# Altium schematic sheet Sheet2.SchDoc(Sheet2)
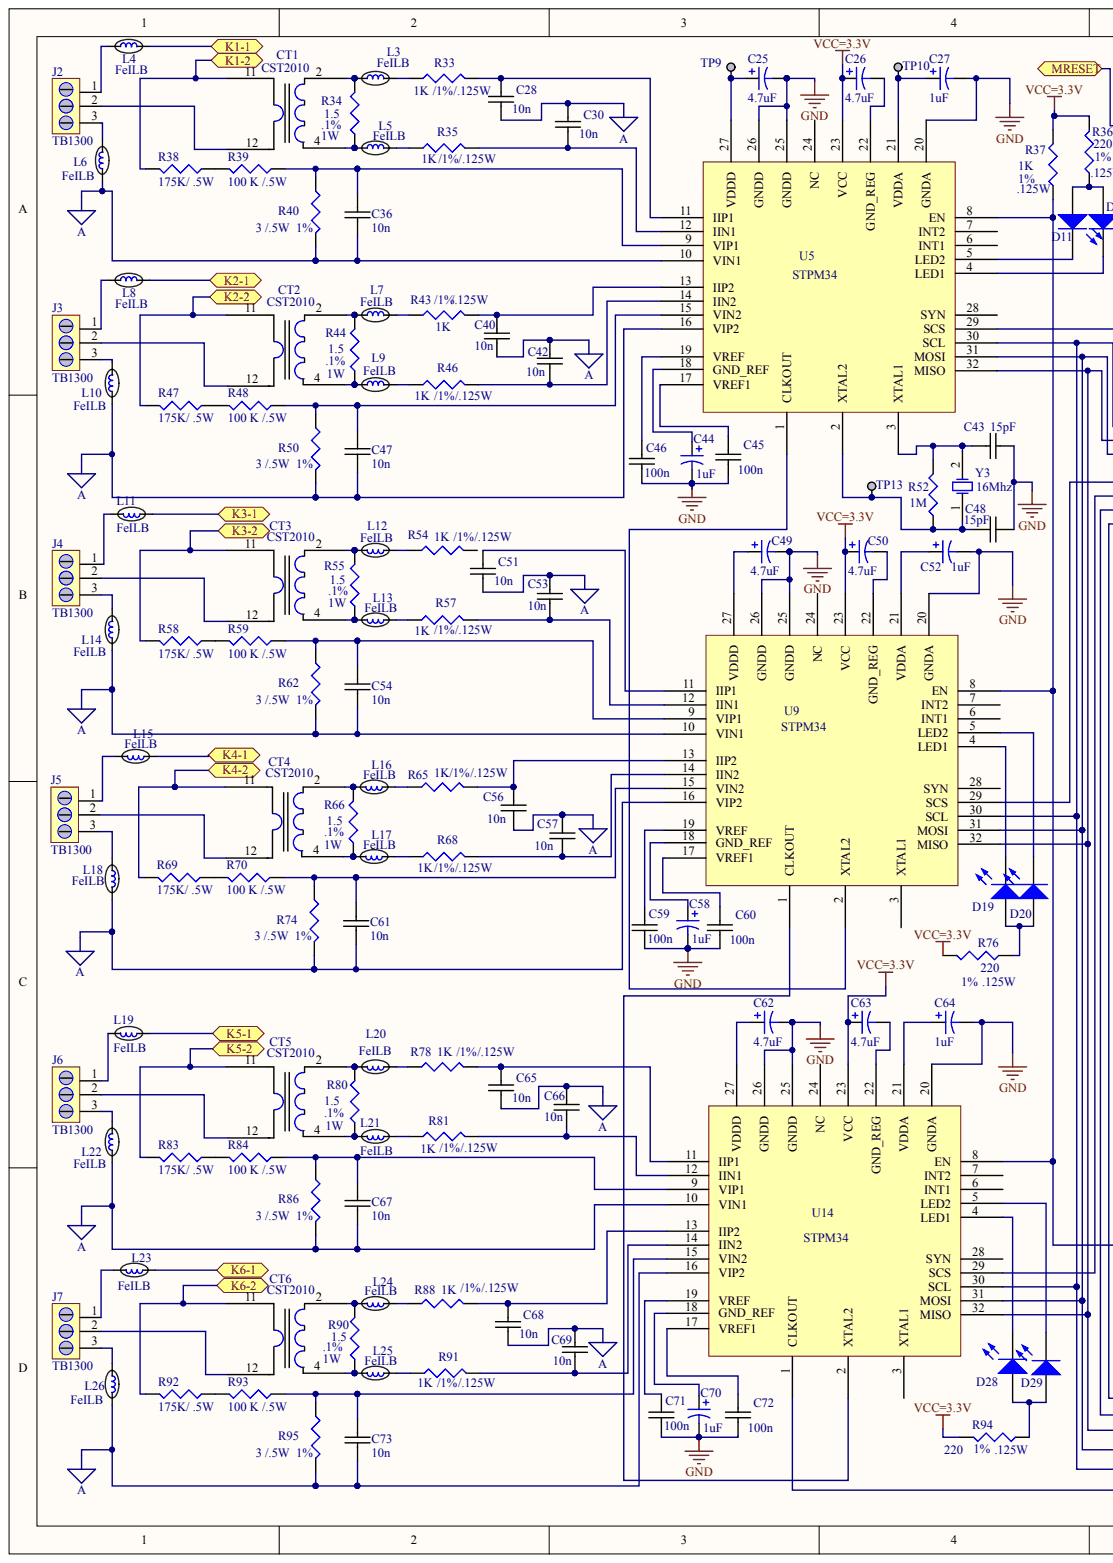
[diagram p0: Sheet2.SchDoc, left]
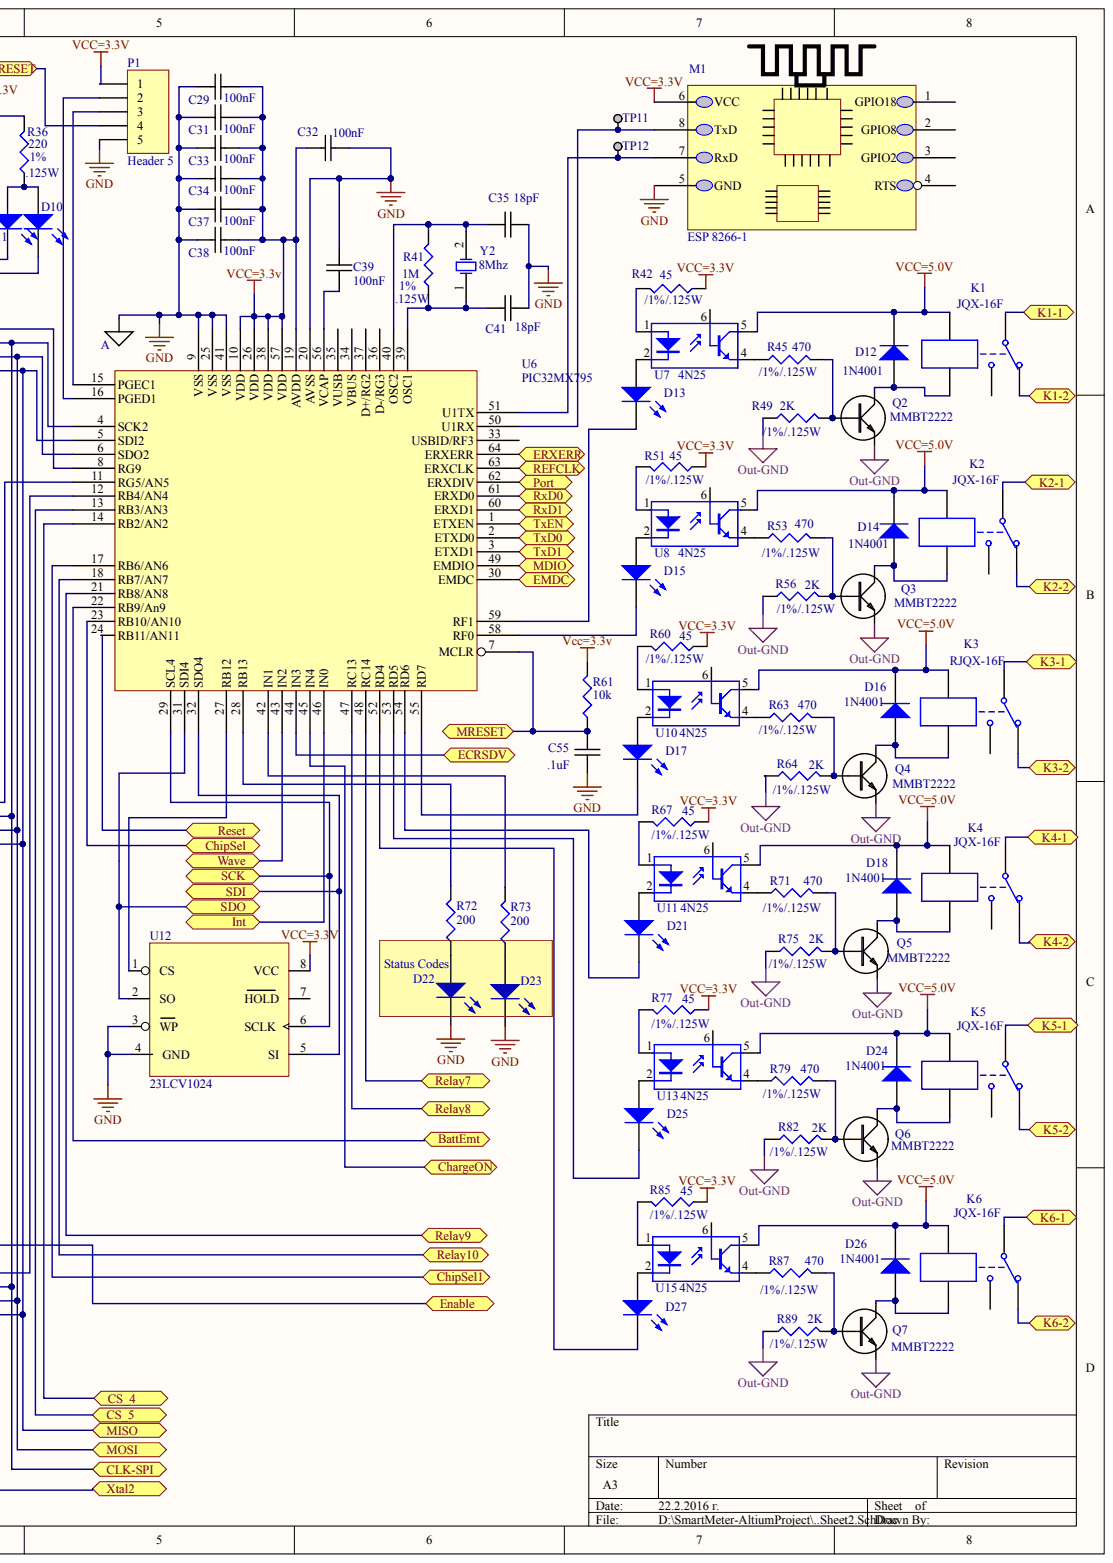
[diagram p1: Sheet2.SchDoc, right]

## Parts
1  AGND  (value not drawn)
C25  4.7uF
C26  4.7uF
C27  1uF
C28  10n
C29  100nF
C30  10n
C31  100nF
C32  100nF
C33  100nF
C34  100nF
C35  18pF
C36  10n
C37  100nF
C38  100nF
C39  100nF
C40  10n
C41  18pF
C42  10n
C43  15pF
C44  1uF
C45  100n
C46  100n
C47  10n
C48  15pF
C49  4.7uF
C50  4.7uF
C51  10n
C52  1uF
C53  10n
C54  10n
C55  .1uF
C56  10n
C57  10n
C58  1uF
C59  100n
C60  100n
C61  10n
C62  4.7uF
C63  4.7uF
C64  1uF
C65  10n
C66  10n
C67  10n
C68  10n
C69  10n
C70  1uF
C71  100n
C72  100n
C73  10n
CT1  CST2010
CT2  CST2010
CT3  CST2010
CT4  CST2010
CT5  CST2010
CT6  CST2010
D10  LED2  (value not drawn)
D11  LED2  (value not drawn)
D12  1N4001
D13  LED2  (value not drawn)
D14  1N4001
D15  LED2  (value not drawn)
D16  1N4001
D17  LED2  (value not drawn)
D18  1N4001
D19  LED2  (value not drawn)
D20  LED2  (value not drawn)
D21  LED2  (value not drawn)
D22  LED2  (value not drawn)
D23  LED2  (value not drawn)
D24  1N4001
D25  LED2  (value not drawn)
D26  1N4001
D27  LED2  (value not drawn)
D28  LED2  (value not drawn)
D29  LED2  (value not drawn)
J2  TB1300
J3  TB1300
J4  TB1300
J5  TB1300
J6  TB1300
J7  TB1300
K1  JQX-16F
K2  JQX-16F
K3  RJQX-16F
K4  JQX-16F
K5  JQX-16F
K6  JQX-16F
L3  FeILB
L4  FeILB
L5  FeILB
L6  FeILB
L7  FeILB
L8  FeILB
L9  FeILB
L10  FeILB
L11  FeILB
L12  FeILB
L13  FeILB
L14  FeILB
L15  FeILB
L16  FeILB
L17  FeILB
L18  FeILB
L19  FeILB
L20  FeILB
L21  FeILB
L22  FeILB
L23  FeILB
L24  FeILB
L25  FeILB
L26  FeILB
M1  ESP 8266-1
P1  Header 5
Q2  MMBT2222
Q3  MMBT2222
Q4  MMBT2222
Q5  MMBT2222
Q6  MMBT2222
Q7  MMBT2222
R33  1K
R34  1.5
R35  1K
R36  220
R37  1K  value 1K 1%
R38  175K/ .5W
R39  100 K /.5W
R40  3 /.5W  1%
R41  1M
R42  45
R43  1K
R44  1.5
R45  470
R46  1K
R47  175K/ .5W
R48  100 K /.5W
R49  2K
R50  3 /.5W  1%
R51  45
R52  1M
R53  470
R54  1K
R55  1.5
R56  2K
R57  1K
R58  175K/ .5W
R59  100 K /.5W
R60  45
R61  10k
R62  3 /.5W  1%
R63  470
R64  2K
R65  1K
R66  1.5
R67  45
R68  1K
R69  175K/ .5W
R70  100 K /.5W
R71  470
R72  200
R73  200
R74  3 /.5W  1%
R75  2K
R76  220
R77  45
R78  1K
R79  470
R80  1.5
R81  1K
R82  2K
R83  175K/ .5W
R84  100 K /.5W
R85  45
R86  3 /.5W  1%
R87  470
R88  1K
R89  2K
R90  1.5
R91  1K
R92  175K/ .5W
R93  100 K /.5W
R94  220
R95  3 /.5W  1%
TP9  TESTPOINT  (value not drawn)
TP10  TESTPOINT  (value not drawn)
TP11  TESTPOINT  (value not drawn)
TP12  TESTPOINT  (value not drawn)
TP13  TESTPOINT  (value not drawn)
U5  STPM34
U6  PIC32MX795
U7  4N25
U8  4N25
U9  STPM34
U10  4N25
U11  4N25
U12  23LCV1024
U13  4N25
U14  STPM34
U15  4N25
Y2  8Mhz
Y3  16Mhz

## Ports
BATTEMT, CHARGEON, CHIPSEL, CHIPSEL1, CLK-SPI, CS_4, CS_5, ECRSDV, EMDC, ENABLE, ERXERR, INT, K1-1, K1-2, K2-1, K2-2, K3-1, K3-2, K4-1, K4-2, K5-1, K5-2, K6-1, K6-2, MDIO, MISO, MOSI, MRESET, PORT, REFCLK, RELAY7, RELAY8, RELAY9, RELAY10, RESET, RXD0, RXD1, SCK, SDI, SDO, TXD0, TXD1, TXEN, WAVE, XTAL2

## Nets
A: C28.1 C30.2 C36.1 C40.1 C42.2 C47.1 C51.1 C53.2 C54.1 C56.1 C57.2 C61.1 C65.1 C66.2 C67.1 C68.1 C69.2 C73.1 L6.1 L10.1 L14.1 L18.2 L22.1 L26.2 R40.2 R50.2 R62.2 R74.2 R86.2 R95.2 U5.10(VIN1) U5.16(VIP2) U9.10(VIN1) U9.16(VIP2) U14.10(VIN1) U14.16(VIP2)
GND: 1.VIN18 C25.2 C27.2 C29.1 C31.1 C32.2 C33.1 C34.1 C35.2 C37.1 C38.1 C39.2 C41.2 C43.2 C44.2 C45.1 C46.1 C48.2 C49.2 C52.2 C55.1 C58.2 C59.1 C60.1 C62.2 C64.2 C70.2 C71.1 C72.1 D22.2 D23.2 M1.5(GND) P1.5 U5.20(GNDA) U5.25(GNDD) U5.26(GNDD) U6.9(VSS) U6.20(AVSS) U6.25(VSS) U6.41(VSS) U9.20(GNDA) U9.25(GNDD) U9.26(GNDD) U12.3(WP) U12.4(GND) U14.20(GNDA) U14.25(GNDD) U14.26(GNDD)
OUT-GND: Q2.E Q3.E Q4.E Q5.E Q6.E Q7.E R49.1 R56.1 R64.1 R75.1 R82.1 R89.1
VCC=3.3V: C26.1 C29.2 C31.2 C32.1 C33.2 C34.2 C37.2 C38.2 C50.1 C63.1 M1.6(VCC) P1.1 R36.2 R37.2 R42.1 R51.1 R60.1 R61.2 R67.1 R76.2 R77.1 R85.1 R94.2 U5.23(VCC) U6.10(VDD) U6.19(AVDD) U6.26(VDD) U6.38(VDD) U6.57(VDD) U9.23(VCC) U12.8(VCC) U14.23(VCC)
VCC=5.0V: D12.2 D14.2 D16.2 D18.2 D24.2 D26.2 K1.4 K2.4 K3.4 K4.4 K5.4 K6.4 U7.5 U8.5 U10.5 U11.5 U13.5 U15.5
CLK-SPI: U5.30(SCL) U6.4(SCK2) U9.30(SCL) U14.30(SCL) ; port CLK-SPI
CS_4: U6.14(RB2/AN2) ; port CS_4
CS_5: U6.13(RB3/AN3) ; port CS_5
ECRSDV: U6.44(IN3) ; port ECRSDV
EMDC: U6.30(EMDC) ; port EMDC
ERXERR: U6.64(ERXERR) ; port ERXERR
K1-1: K1.1 L4.2 ; port K1-1
K1-2: CT1.11 K1.2 R38.2 ; port K1-2
K2-1: K2.1 L8.2 ; port K2-1
K2-2: CT2.11 K2.2 R47.2 ; port K2-2
K3-1: K3.1 L11.1 ; port K3-1
K3-2: CT3.11 K3.2 R58.2 ; port K3-2
K4-1: K4.1 L15.1 ; port K4-1
K4-2: CT4.11 K4.2 R69.2 ; port K4-2
K5-1: K5.1 L19.1 ; port K5-1
K5-2: CT5.11 K5.2 R83.2 ; port K5-2
K6-1: K6.1 L23.1 ; port K6-1
K6-2: CT6.11 K6.2 R92.2 ; port K6-2
MDIO: U6.49(EMDIO) ; port MDIO
MISO: U5.32(MISO) U6.5(SDI2) U9.32(MISO) U14.32(MISO) ; port MISO
MOSI: U5.31(MOSI) U6.6(SDO2) U9.31(MOSI) U14.31(MOSI) ; port MOSI
MRESET: C55.2 P1.4 R61.1 U6.7(MCLR) ; port MRESET
REFCLK: U6.63(ERXCLK) ; port REFCLK
SCK: U6.29(SCL4) U12.6(SCLK) ; port SCK
SDI: U6.32(SDO4) U12.5(SI) ; port SDI
SDO: U6.31(SDI4) U12.2(SO) ; port SDO
NetC25_1: C25.1 TP9.1 U5.27(VDDD)
NetC26_2: C26.2 U5.22(GND_REG)
NetC27_1: C27.1 TP10.1 U5.21(VDDA)
NetC28_2: C28.2 R33.2 U5.11(IIP1)
NetC30_1: C30.1 R35.2 U5.12(IIN1)
NetC35_1: C35.1 R41.2 U6.40(OSC2) Y2.2
NetC36_2: C36.2 R39.1 R40.1 U5.9(VIP1)
NetC39_1: C39.1 U6.56(VCAP)
NetC40_2: C40.2 R43.2 U5.13(IIP2)
NetC41_1: C41.1 R41.1 U6.39(OSC1) Y2.1
NetC42_1: C42.1 R46.2 U5.14(IIN2)
NetC43_1: C43.1 R52.2 U5.3(XTAL1) Y3.2
NetC44_1: C44.1 U5.18(GND_REF)
NetC45_2: C45.2 U5.17(VREF1)
NetC46_2: C46.2 U5.19(VREF)
NetC47_2: C47.2 R48.1 R50.1 U5.15(VIN2)
NetC48_1: C48.1 R52.1 TP13.1 U5.2(XTAL2) Y3.1
NetC49_1: C49.1 U9.27(VDDD)
NetC50_2: C50.2 U9.22(GND_REG)
NetC51_2: C51.2 U9.11(IIP1)
NetC52_1: C52.1 U9.21(VDDA)
NetC53_1: C53.1 R57.2 U9.12(IIN1)
NetC54_2: C54.2 R59.1 R62.1 U9.9(VIP1)
NetC56_2: C56.2 R65.2 U9.13(IIP2)
NetC57_1: C57.1 R68.2 U9.14(IIN2)
NetC58_1: C58.1 U9.18(GND_REF)
NetC59_2: C59.2 U9.19(VREF)
NetC60_2: C60.2 U9.17(VREF1)
NetC61_2: C61.2 R70.1 R74.1 U9.15(VIN2)
NetC62_1: C62.1 U14.27(VDDD)
NetC63_2: C63.2 U14.22(GND_REG)
NetC64_1: C64.1 U14.21(VDDA)
NetC65_2: C65.2 R78.2 U14.11(IIP1)
NetC66_1: C66.1 R81.2 U14.12(IIN1)
NetC67_2: C67.2 R84.1 R86.1 U14.9(VIP1)
NetC68_2: C68.2 R88.2 U14.13(IIP2)
NetC69_1: C69.1 R91.2 U14.14(IIN2)
NetC70_1: C70.1 U14.18(GND_REF)
NetC71_2: C71.2 U14.19(VREF)
NetC72_2: C72.2 U14.17(VREF1)
NetC73_2: C73.2 R93.1 R95.1 U14.15(VIN2)
NetCT1_2: CT1.2 L3.1 R34.2
NetCT1_4: CT1.4 L5.1 R34.1
NetCT1_12: CT1.12 J2.2
NetCT2_2: CT2.2 L7.1 R44.2
NetCT2_4: CT2.4 L9.1 R44.1
NetCT2_12: CT2.12 J3.2
NetCT3_2: CT3.2 L12.2 R55.2
NetCT3_4: CT3.4 L13.2 R55.1
NetCT3_12: CT3.12 J4.2
NetCT4_2: CT4.2 L16.2 R66.2
NetCT4_4: CT4.4 L17.2 R66.1
NetCT4_12: CT4.12 J5.2
NetCT5_2: CT5.2 L20.2 R80.2
NetCT5_4: CT5.4 L21.2 R80.1
NetCT5_12: CT5.12 J6.2
NetCT6_2: CT6.2 L24.2 R90.2
NetCT6_4: CT6.4 L25.2 R90.1
NetCT6_12: CT6.12 J7.2
NetD10_1: D10.1 D11.1 R36.1
NetD10_2: D10.2 U5.4(LED1)
NetD11_2: D11.2 U5.5(LED2)
NetD12_1: D12.1 K1.5 Q2.C
NetD13_1: D13.1 U7.2
NetD13_2: D13.2 U6.59(RF1)
NetD14_1: D14.1 K2.5 Q3.C
NetD15_1: D15.1 U8.2
NetD15_2: D15.2 U6.58(RF0)
NetD16_1: D16.1 K3.5 Q4.C
NetD17_1: D17.1 U10.2
NetD17_2: D17.2 U6.55(RD7)
NetD18_1: D18.1 K4.5 Q5.C
NetD19_1: D19.1 D20.1 R76.1
NetD19_2: D19.2 U9.4(LED1)
NetD20_2: D20.2 U9.5(LED2)
NetD21_1: D21.1 U11.2
NetD21_2: D21.2 U6.54(RD6)
NetD22_1: D22.1 R72.1
NetD23_1: D23.1 R73.1
NetD24_1: D24.1 K5.5 Q6.C
NetD25_1: D25.1 U13.2
NetD25_2: D25.2 U6.53(RD5)
NetD26_1: D26.1 K6.5 Q7.C
NetD27_1: D27.1 U15.2
NetD27_2: D27.2 U6.52(RD4)
NetD28_1: D28.1 D29.1 R94.1
NetD28_2: D28.2 U14.4(LED1)
NetD29_2: D29.2 U14.5(LED2)
NetJ2_1: J2.1 L4.1
NetJ2_3: J2.3 L6.2
NetJ3_1: J3.1 L8.1
NetJ3_3: J3.3 L10.2
NetJ4_1: J4.1 L11.2
NetJ4_3: J4.3 L14.2
NetJ5_1: J5.1 L15.2
NetJ5_3: J5.3 L18.1
NetJ6_1: J6.1 L19.2
NetJ6_3: J6.3 L22.2
NetJ7_1: J7.1 L23.2
NetJ7_3: J7.3 L26.1
NetL3_2: L3.2 R33.1
NetL5_2: L5.2 R35.1
NetL7_2: L7.2 R43.1
NetL9_2: L9.2 R46.1
NetL12_1: L12.1 R54.1
NetL13_1: L13.1 R57.1
NetL16_1: L16.1 R65.1
NetL17_1: L17.1 R68.1
NetL20_1: L20.1 R78.1
NetL21_1: L21.1 R81.1
NetL24_1: L24.1 R88.1
NetL25_1: L25.1 R91.1
NetM1_7: M1.7(RxD) TP12.1 U6.51(U1TX)
NetM1_8: M1.8(TxD) TP11.1 U6.50(U1RX)
NetP1_2: P1.2 U6.16(PGED1)
NetP1_3: P1.3 U6.15(PGEC1)
NetQ2_B: Q2.B R45.2 R49.2
NetQ3_B: Q3.B R53.2 R56.2
NetQ4_B: Q4.B R63.2 R64.2
NetQ5_B: Q5.B R71.2 R75.2
NetQ6_B: Q6.B R79.2 R82.2
NetQ7_B: Q7.B R87.2 R89.2
NetR37_1: R37.1 U5.8(EN) U9.8(EN) U14.8(EN) ; port ENABLE
NetR38_1: R38.1 R39.2
NetR42_2: R42.2 U7.1
NetR45_1: R45.1 U7.4
NetR47_1: R47.1 R48.2
NetR51_2: R51.2 U8.1
NetR53_1: R53.1 U8.4
NetR58_1: R58.1 R59.2
NetR60_2: R60.2 U10.1
NetR63_1: R63.1 U10.4
NetR67_2: R67.2 U11.1
NetR69_1: R69.1 R70.2
NetR71_1: R71.1 U11.4
NetR72_2: R72.2 U6.28(RB13)
NetR73_2: R73.2 U6.42(IN1)
NetR77_2: R77.2 U13.1
NetR79_1: R79.1 U13.4
NetR83_1: R83.1 R84.2
NetR85_2: R85.2 U15.1
NetR87_1: R87.1 U15.4
NetR92_1: R92.1 R93.2
NetU5_1: U5.1(CLKOUT) U9.2(XTAL2)
NetU5_29: U5.29(SCS) U6.8(RG9)
NetU6_1: U6.1(ETXEN) ; port TXEN
NetU6_2: U6.2(ETXD0) ; port TXD0
NetU6_3: U6.3(ETXD1) ; port TXD1
NetU6_11: U6.11(RG5/AN5) U9.29(SCS)
NetU6_12: U6.12(RB4/AN4) U14.29(SCS)
NetU6_17: U6.17(RB6/AN6) ; port CHIPSEL1
NetU6_18: U6.18(RB7/AN7) ; port RELAY10
NetU6_21: U6.21(RB8/AN8) ; port RELAY9
NetU6_22: U6.22(RB9/An9) ; port BATTEMT
NetU6_23: U6.23(RB10/AN10) ; port CHIPSEL
NetU6_24: U6.24(RB11/AN11) ; port RESET
NetU6_27: U6.27(RB12) U12.1(CS)
NetU6_43: U6.43(IN2) ; port WAVE
NetU6_45: U6.45(IN4) ; port CHARGEON
NetU6_46: U6.46(IN0) ; port INT
NetU6_47: U6.47(RC13) ; port RELAY8
NetU6_48: U6.48(RC14) ; port RELAY7
NetU6_60: U6.60(ERXD1) ; port RXD1
NetU6_61: U6.61(ERXD0) ; port RXD0
NetU6_62: U6.62(ERXDIV) ; port PORT
NetU9_1: U9.1(CLKOUT) U14.2(XTAL2)
NetU14_1: U14.1(CLKOUT) ; port XTAL2

Not connected: K1 3; K2 3; K3 3; K4 3; K5 3; K6 3; M1 1(GPIO18) 2(GPIO8) 3(GPIO2) 4(RTS); R54 2; U5 6(INT1) 7(INT2) 24(NC) 28(SYN); U6 33(USBID/RF3) 34(VBUS) 35(VUSB) 36(D-/RG3) 37(D+/RG2); U7 6; U8 6; U9 3(XTAL1) 6(INT1) 7(INT2) 24(NC) 28(SYN); U10 6; U11 6; U12 7(HOLD); U13 6; U14 3(XTAL1) 6(INT1) 7(INT2) 24(NC) 28(SYN); U15 6

## Library data of the parts
C25-C27 C44 C49 C50 C52 C58 C62-C64 C70 = (no symbol name): Value: 100pF; Published: 8-Jun-2000; LatestRevisionDate: 29-May-2009; LatestRevisionNote: IPC-7351 Footprint Added.; PackageReference: C0805; PackageDescription: Chip Capacitor; Publisher: Altium Limited
C45 C46 C59 C60 C71 C72 = (no symbol name): Value: 10n; Supplier 1: Digi-Key; Supplier Part Number 1: 709-1171-2-ND; mfr PN: *; Package reference: 0805-TBD
R33-R36 R41 R43 R44 R46 R52 R54 R55 R57 R65 R66 R68 R76 R78 R80 R81 R88 R90 R91 R94 = (no symbol name): Value: 1K 1%; Published: 8-Jun-2000; LatestRevisionDate: 29-May-2009; LatestRevisionNote: IPC-7351 Footprint Added.; PackageReference: J1-0603; PackageDescription: Chip Resistor; Publisher: Altium Limited
  R35 R36 R41 R46 R52 R57 R68 R76 R81 R91 R94: manufacture P/N: *
R38 R47 R58 R69 R83 R92 = (no symbol name): Value: 175k/ .5 W 1%; Published: 8-Jun-2000; LatestRevisionDate: 29-May-2009; LatestRevisionNote: IPC-7351 Footprint Added.; PackageReference: J1-0603; PackageDescription: Chip Resistor; mfr PN: *; Package Reference: 1206; Publisher: Altium Limited
R39 R48 R59 R70 R84 R93 = (no symbol name): Value: 100k /.5 W 1%; Published: 8-Jun-2000; LatestRevisionDate: 29-May-2009; LatestRevisionNote: IPC-7351 Footprint Added.; PackageReference: J1-0603; PackageDescription: Chip Resistor; mfr PN: *; Package Reference: 1206; Publisher: Altium Limited
R40 R50 R62 R74 R86 R95 = (no symbol name): Value: 3 .125W 1%; Published: 8-Jun-2000; LatestRevisionDate: 29-May-2009; LatestRevisionNote: IPC-7351 Footprint Added.; PackageReference: J1-0603; PackageDescription: Chip Resistor; Publisher: Altium Limited
R42 R51 R60 R67 R77 R85 = (no symbol name): Value: 45/.125/1%; Published: 8-Jun-2000; LatestRevisionDate: 29-May-2009; LatestRevisionNote: IPC-7351 Footprint Added.; PackageReference: J1-0603; PackageDescription: Chip Resistor; mfr PN: *; Publisher: Altium Limited
R45 R53 R63 R71 R79 R87 = (no symbol name): Value: 470/.125W/1%; Published: 8-Jun-2000; LatestRevisionDate: 29-May-2009; LatestRevisionNote: IPC-7351 Footprint Added.; PackageReference: J1-0603; PackageDescription: Chip Resistor; Publisher: Altium Limited
R49 R56 R64 R75 R82 R89 = (no symbol name): Value: 2K/.125W/1%; Published: 8-Jun-2000; LatestRevisionDate: 29-May-2009; LatestRevisionNote: IPC-7351 Footprint Added.; PackageReference: J1-0603; PackageDescription: Chip Resistor; Publisher: Altium Limited
R61 = (no symbol name): Value: 10k/.125W/1%; Published: 8-Jun-2000; LatestRevisionDate: 29-May-2009; LatestRevisionNote: IPC-7351 Footprint Added.; PackageReference: J1-0603; PackageDescription: Chip Resistor; Publisher: Altium Limited
R72 R73 = (no symbol name): Value: 200/.125W/1%; Published: 8-Jun-2000; LatestRevisionDate: 29-May-2009; LatestRevisionNote: IPC-7351 Footprint Added.; PackageReference: J1-0603; PackageDescription: Chip Resistor; Publisher: Altium Limited
TP9-TP13 = (no symbol name): Manufacturer Part Number: 5010; Manufacturer: Keystone Electronics; Reel: TH; Supplier 1: Digi-Key; Supplier Part Number 1: 5010K-ND
1 C28-C43 C47 C48 C51 C53-C57 C61 C65-C69 C73 CT1-CT6 D10-D29 J2-J7 K1-K6 L3-L26 M1 P1 Q2-Q7 R37 U5-U15 Y2 Y3 = (no symbol name)
  C28 C30 C40 C42 C51 C53 C56 C57 C65 C66 C68 C69: Supplier 1: Digi-Key; Supplier Part Number 1: 709-1171-2-ND; Value: 10n; mfr PN: *; Package reference: 0805-TBD
  C29 C31-C35 C37-C39 C41 C43 C48 C55: Supplier 1: Digi-Key; Supplier Part Number 1: 709-1171-2-ND
  C36 C47 C54 C61 C67 C73: Supplier 1: Digi-Key; Supplier Part Number 1: 709-1171-2-ND; Value: 10n; mfr PN: *; Package Reference: 0805-TBD
  D10 D11 D13 D15 D17 D19-D23 D25 D27-D29: Published: 8-Jun-2000; LatestRevisionDate: 17-Jul-2002; LatestRevisionNote: Re-released for DXP Platform.; PackageVersion: Feb-2002; PackageReference: 3.2X1.6X1.1; PackageDescription: SM LED; 2 Flat Leads
  D12 D14 D16 D18 D24 D26: Published: 8-Jun-2000; LatestRevisionDate: 17-Jul-2002; LatestRevisionNote: Re-released for DXP Platform.; DatasheetVersion: 1998; PackageVersion: Aug-1999; PackageReference: DO-41; PackageDescription: Diode, Axial; Body 5.2 x 2.7 mm (LxDia. max), Lead Dia. 0.86 mm (max); Code_JEDEC: DO-204-AL; ComponentLink1Description: Manufacturer Link; ComponentLink1URL: http://www.fairchildsemi.com/; ComponentLink2Description: Datasheet; ComponentLink2URL: http://www.fairchildsemi.com/ds/1N%2F1N4001.pdf
  K1-K6: Published: 24-Mar-1999; LatestRevisionDate: 17-Jul-2002; LatestRevisionNote: Re-released for DXP Platform.; PackageReference: MODULE5B
  P1: LatestRevisionDate: 17-Jul-2002; LatestRevisionNote: Re-released for DXP Platform.
  Q2-Q7: Supplier 1: Digi-Key; Supplier Part Number 1: MMBT2222A-FDICT-ND
  R37: Published: 8-Jun-2000; LatestRevisionDate: 29-May-2009; LatestRevisionNote: IPC-7351 Footprint Added.; PackageReference: J1-0603; PackageDescription: Chip Resistor; manufacture P/N: *
  U7 U8 U10 U11 U13 U15: Published: 24-Mar-1999; LatestRevisionDate: 04-Mar-2005; LatestRevisionNote: PCB Footprint replaced, Sch pins re-numbered.; DatasheetVersion: Apr-2004; PackageReference: SOP5(6); PackageDescription: Small Outline; 6 Leads (1 Absent); Body Width 4.4 mm; Pitch 1.27 mm
  Y2 Y3: Published: 8-Jun-2000; LatestRevisionDate: 17-Jul-2002; LatestRevisionNote: Re-released for DXP Platform.; PackageReference: R38; PackageDescription: Crystal, Thru-Hole; 2 Leads; Body 3.1 x 8.2 mm (Dia.xH)
  D10-D29 K1-K6 P1 R37 U7 U8 U10 U11 U13 U15 Y2 Y3: Publisher: Altium Limited
